# Altium schematic sheet [07] - AUDIO AND MIC.SchDoc("[07] - AUDIO AND MIC")
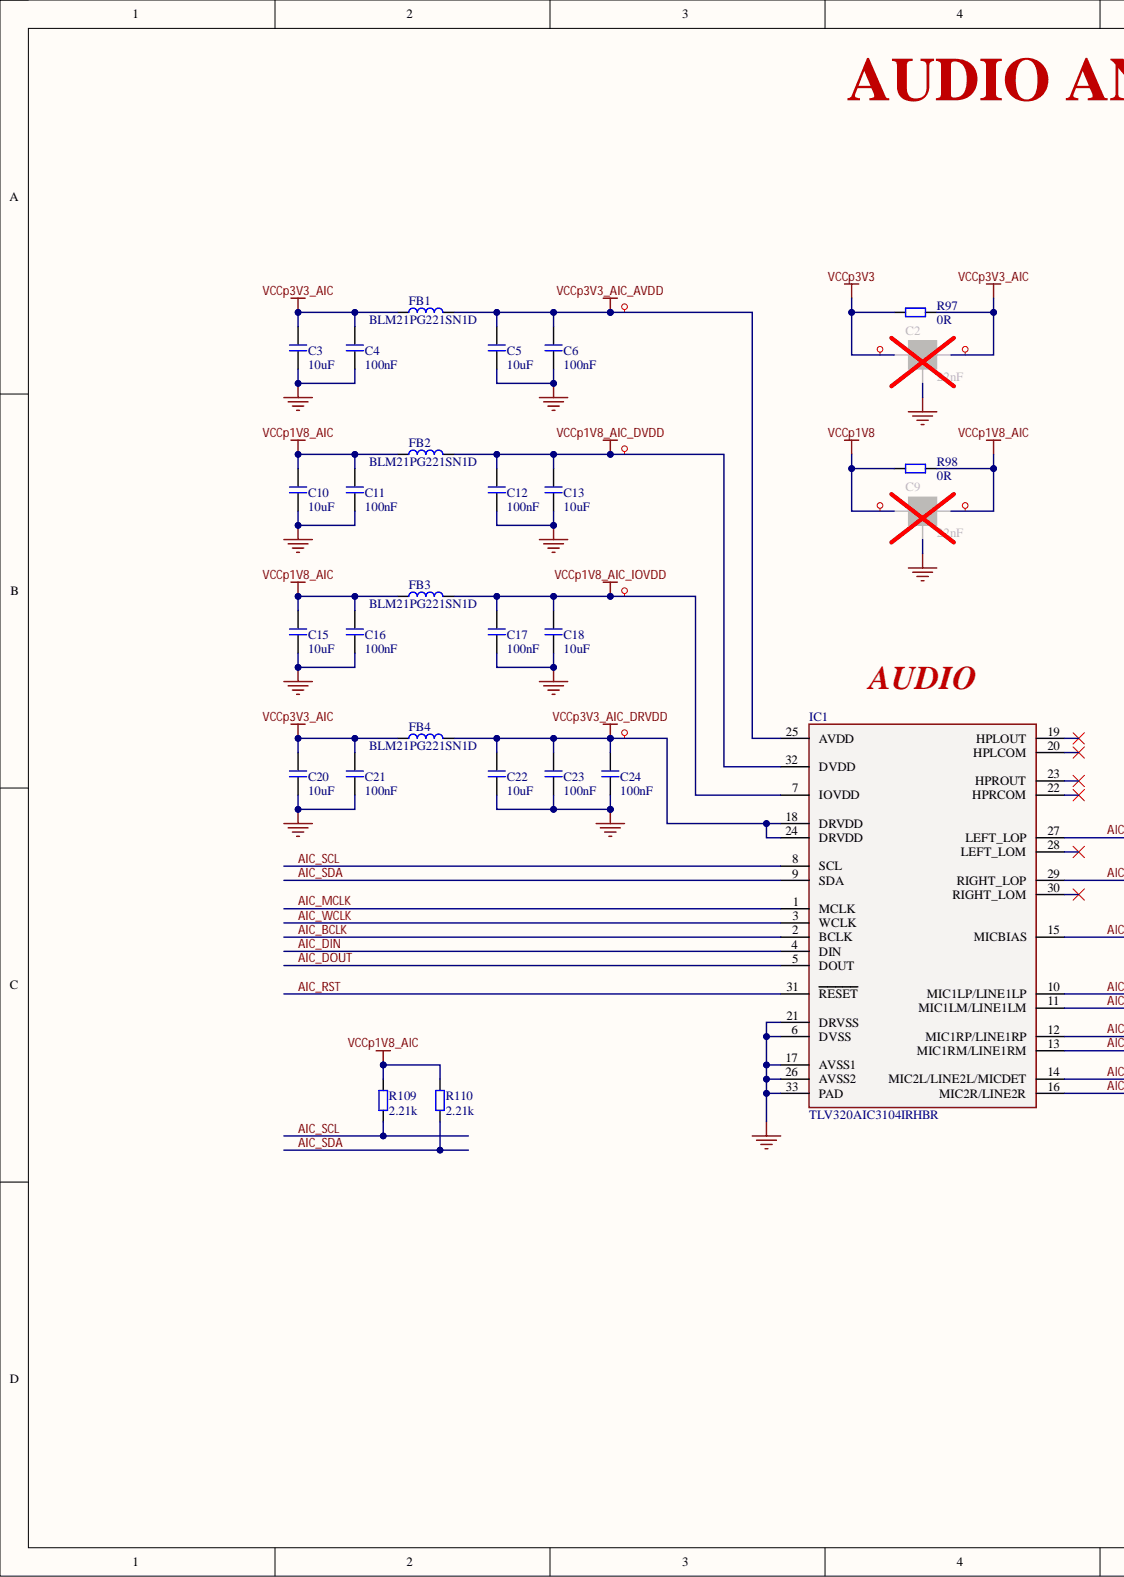
[diagram p0: [07] - AUDIO AND MIC.SchDoc, left]
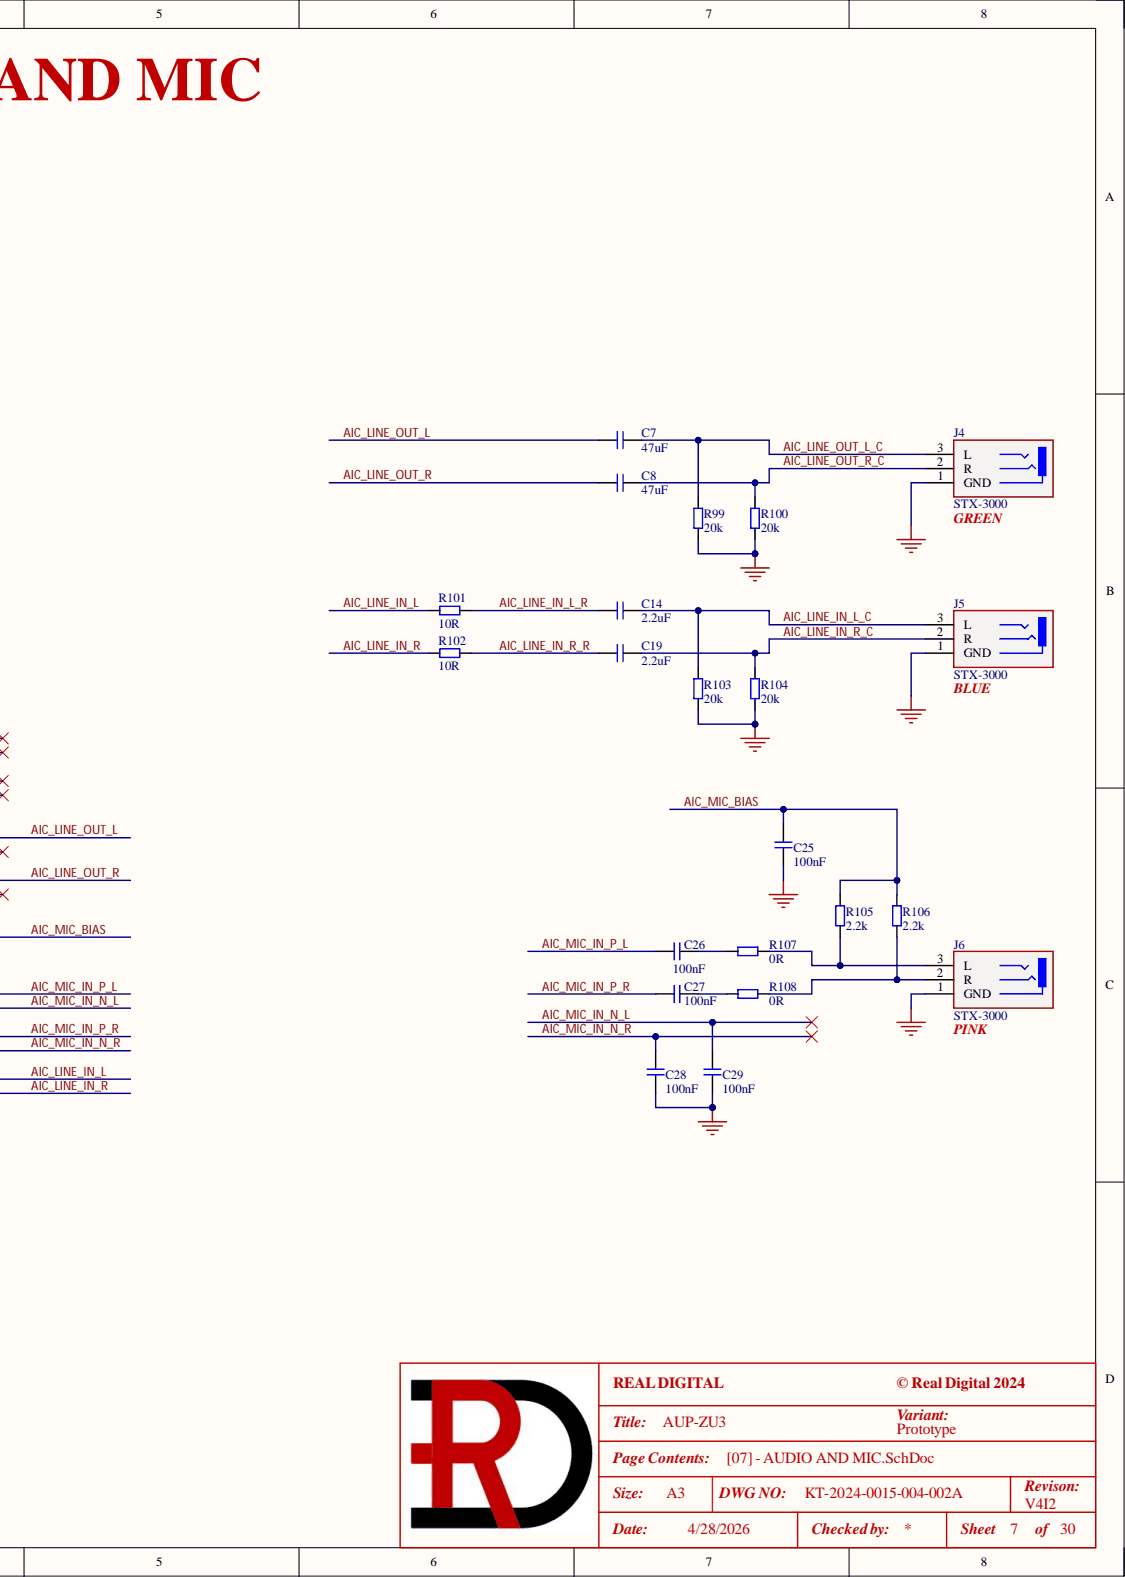
[diagram p1: [07] - AUDIO AND MIC.SchDoc, right]

## Parts
C2  22nF  [NFM31PC/31KC]
C3  10uF  [C0402]
C4  100nF  [C0402]
C5  10uF  [C0402]
C6  100nF  [C0402]
C7  47uF  [C1206]
C8  47uF  [C1206]
C9  22nF  [NFM31PC/31KC]
C10  10uF  [C0402]
C11  100nF  [C0402]
C12  100nF  [C0402]
C13  10uF  [C0402]
C14  2.2uF  [C0402]
C15  10uF  [C0402]
C16  100nF  [C0402]
C17  100nF  [C0402]
C18  10uF  [C0402]
C19  2.2uF  [C0402]
C20  10uF  [C0402]
C21  100nF  [C0402]
C22  10uF  [C0402]
C23  100nF  [C0402]
C24  100nF  [C0402]
C25  100nF  [C0402]
C26  100nF  [C0805]
C27  100nF  [C0805]
C28  100nF  [C0805]
C29  100nF  [C0805]
FB1  BLM21PG221SN1D  [BLM21]
FB2  BLM21PG221SN1D  [BLM21]
FB3  BLM21PG221SN1D  [BLM21]
FB4  BLM21PG221SN1D  [BLM21]
IC1  TLV320AIC3104IRHBR  [RHB0032E_VQFN-32 - TI]
J4  STX-3000  [PJD-035-27SDB]
J5  STX-3000  [PJD-035-27SDB]
J6  STX-3000  [PJD-035-27SDB]
R97  0R  [R0805]
R98  0R  [R0805]
R99  20k  [R0402]
R100  20k  [R0402]
R101  10R  [R0402]
R102  10R  [R0402]
R103  20k  [R0402]
R104  20k  [R0402]
R105  2.2k  [R0402]
R106  2.2k  [R0402]
R107  0R  [R0805]
R108  0R  [R0805]
R109  2.21k  [R0402]
R110  2.21k  [R0402]

## Nets
GND: C2.2 C3.1 C4.1 C5.1 C6.1 C9.2 C10.1 C11.1 C12.1 C13.1 C15.1 C16.1 C17.1 C18.1 C20.1 C21.1 C22.1 C23.1 C24.1 C25.2 C28.1 C29.1 IC1.6(DVSS) IC1.17(AVSS1) IC1.21(DRVSS) IC1.26(AVSS2) IC1.33(PAD) J4.1 J5.1 J6.1 R99.1 R100.1 R103.1 R104.1
VCCp1V8: C9.1 R98.2
VCCp1V8_AIC: C9.3 C10.2 C11.2 C15.2 C16.2 FB2.1 FB3.1 R98.1 R109.2 R110.2
VCCp1V8_AIC_DVDD: C12.2 C13.2 FB2.2 IC1.32(DVDD)
VCCp1V8_AIC_IOVDD: C17.2 C18.2 FB3.2 IC1.7(IOVDD)
VCCp3V3: C2.1 R97.2
VCCp3V3_AIC: C2.3 C3.2 C4.2 C20.2 C21.2 FB1.1 FB4.1 R97.1
VCCp3V3_AIC_AVDD: C5.2 C6.2 FB1.2 IC1.25(AVDD)
VCCp3V3_AIC_DRVDD: C22.2 C23.2 C24.2 FB4.2 IC1.18(DRVDD) IC1.24(DRVDD)
AIC_BCLK: IC1.2(BCLK)
AIC_DIN: IC1.4(DIN)
AIC_DOUT: IC1.5(DOUT)
AIC_LINE_IN_L: IC1.14(MIC2L/LINE2L/MICDET) R101.1
AIC_LINE_IN_L_C: C14.2 J5.3 R103.2
AIC_LINE_IN_L_R: C14.1 R101.2
AIC_LINE_IN_R: IC1.16(MIC2R/LINE2R) R102.1
AIC_LINE_IN_R_C: C19.2 J5.2 R104.2
AIC_LINE_IN_R_R: C19.1 R102.2
AIC_LINE_OUT_L: C7.1 IC1.27(LEFT_LOP)
AIC_LINE_OUT_L_C: C7.2 J4.3 R99.2
AIC_LINE_OUT_R: C8.1 IC1.29(RIGHT_LOP)
AIC_LINE_OUT_R_C: C8.2 J4.2 R100.2
AIC_MCLK: IC1.1(MCLK)
AIC_MIC_BIAS: C25.1 IC1.15(MICBIAS) R105.2 R106.2
AIC_MIC_IN_N_L: C29.2 IC1.11(MIC1LM/LINE1LM)
AIC_MIC_IN_N_R: C28.2 IC1.13(MIC1RM/LINE1RM)
AIC_MIC_IN_P_L: C26.1 IC1.10(MIC1LP/LINE1LP)
AIC_MIC_IN_P_R: C27.1 IC1.12(MIC1RP/LINE1RP)
AIC_RST: IC1.31(RESET)
AIC_SCL: IC1.8(SCL) R109.1
AIC_SDA: IC1.9(SDA) R110.1
AIC_WCLK: IC1.3(WCLK)
NetC26_2: C26.2 R107.1
NetC27_2: C27.2 R108.1
NetJ6_2: J6.2 R106.1 R108.2
NetJ6_3: J6.3 R105.1 R107.2

Not connected: IC1 19(HPLOUT) 20(HPLCOM) 22(HPRCOM) 23(HPROUT) 28(LEFT_LOM) 30(RIGHT_LOM)

## Library data of the parts
C2 C9 = NFM31HK223R1H3: CAP FEEDTHRU 0.022UF 50V 1206; Manufacturer 1: Murata Electronics; Manufacturer 1 Part Number: NFM31HK223R1H3L; .Checked_PCB: RV; C P/N: RD-111-0025; LoadStatus: Load; Category: Capacitors; Component Kind: Standard; Library Name: KT-RealDigital.IntLib; .Checked_SCH: RV; .Creator: RV
C3 C5 C10 C13 C15 C18 C20 C22 = GRM155R61A106ME11D: CAP CER 10uF 10V X5R 0402; Manufacturer 1: Murata Electronics; .Checked_PCB: RV; C P/N: RD-111-0065; LoadStatus: Load; Tolerance: 20%; Category: Capacitors; Component Kind: Standard; Library Name: KT-RealDigital.IntLib; Manufacturer 1 Part Number: GRM155R61A106ME11D; Temperature Coefficient: X5R; Voltage - Rated: 10V; .Checked_SCH: RV; .Creator: RV
C4 C6 C11 C12 C16 C17 C21 C23-C25 = GRM155R71H104ME14J: CAP CER 0.1uF 50V X7R 0402; Manufacturer 1: Murata Electronics; .Checked_PCB: DG; .Creator: RV; C P/N: RD-111-0069; LoadStatus: Load; Tolerance: 20%; Category: Capacitors; Component Kind: Standard; Library Name: KT-RealDigital.IntLib; Manufacturer 1 Part Number: GRM155R71H104ME14J; Temperature Coefficient: X7R; Voltage - Rated: 50V; .Checked_SCH: DG
C7 C8 = GRM31CR60J476ME19L: CAP CER 47uF 6.3V X5R 1206; Manufacturer 1: Murata Electronics; .Checked_PCB: RV; C P/N: RD-111-0098; LoadStatus: Load; Tolerance: 20%; Category: Capacitors; Component Kind: Standard; Library Name: KT-RealDigital.IntLib; Manufacturer 1 Part Number: GRM31CR60J476ME19L; Temperature Coefficient: X5R; Voltage - Rated: 6.3V; .Checked_SCH: RV; .Creator: RV
C14 C19 = GRM155R61E225KE11D: CAP CER 2.2uF 25V X5R 0402; Manufacturer 1: Murata Electronics; .Checked_PCB: RV; C P/N: RD-111-0099; LoadStatus: Load; Tolerance: 10%; Category: Capacitors; Component Kind: Standard; Library Name: KT-RealDigital.IntLib; Manufacturer 1 Part Number: GRM155R61E225KE11D; Temperature Coefficient: X5R; Voltage - Rated: 25V; .Checked_SCH: RV; .Creator: RV
C26-C29 = GCM21BR72A104KA37K: CAP CER 0.1uF 100V X7R 0805; Manufacturer 1: Murata Electronics; .Checked_PCB: RV; C P/N: 111-0782; LoadStatus: Load; Tolerance: 10%; Category: Capacitors; Component Kind: Standard; Library Name: KT-RealDigital.IntLib; Manufacturer 1 Part Number: GCM21BR72A104KA37K; Temperature Coefficient: X7R; Voltage - Rated: 100V; .Checked_SCH: RV; .Creator: RV
FB1-FB4 = BLM21PG221SN1D: FERRITE BEAD 220 OHM 0805 1LN; Manufacturer 1: Murata Electronics; .Checked_PCB: RV; C P/N: 131-0206; LoadStatus: Load; Category: Ferrite Beads; Component Kind: Standard; Library Name: KT-RealDigital.IntLib; Manufacturer 1 Part Number: BLM21PG221SN1D; .Checked_SCH: RV; .Creator: RV
IC1 = TLV320AIC3104IRHBR: Low-Power Stereo Audio Codec for Portable Audio and Telephony; Manufacturer 1: Texas Instruments; .Checked_PCB: RV; C P/N: RD-126-1522; LoadStatus: Load; Category: IC; Component Kind: Standard; Library Name: KT-RealDigital.IntLib; Manufacturer 1 Part Number: TLV320AIC3104IRHBR; .Checked_SCH: RV; .Creator: RV
J4-J6 = STX-3000: 3.50mm (0.141", 1/8", Mini Plug) - Headphone Phone Jack Stereo (3 Conductor, TRS) Connector Kinked Pin; Manufacturer 1: Kycon, Inc.; .Checked_PCB: AC; .Creator: RV; C P/N: 163-0000; LoadStatus: Load; Category: Connector; Component Kind: Standard; Library Name: KT-RealDigital.IntLib; Manufacturer 1 Part Number: STX-3000; Manufacturer 2: JKCR Electronics Co., Ltd.; Manufacturer 2 Part Number: PJD-035-27SDB; .Checked_SCH: AC
R97 R98 R107 R108 = RC0805JR-070RL: RES SMD 0 OHM JUMPER 1/8W 0805; Manufacturer 1: Yageo; .Checked_PCB: AC; .Creator: RV; C P/N: RD-101-0006; LoadStatus: Load; Category: Resistors; Component Kind: Standard; Library Name: KT-RealDigital.IntLib; Manufacturer 1 Part Number: RC0805JR-070RL; Tolerance: Jumper; .Checked_SCH: AC
R99 R100 R103 R104 = RT0402BRD0720KL: RES SMD 20K OHM 0.1% 1/16W 0402; Manufacturer 1: Yageo; .Checked_PCB: AC; .Creator: RV; C P/N: 101-1361; LoadStatus: Load; Tolerance: 0.1%/TK25; Category: Resistors; Component Kind: Standard; Library Name: KT-RealDigital.IntLib; Manufacturer 1 Part Number: RT0402BRD0720KL; .Checked_SCH: AC
R101 R102 = RC0402FR-0710RL: RES SMD 10 OHM 1% 1/16W 0402; Manufacturer 1: Yageo; .Checked_PCB: RV; C P/N: CY-101-0388; LoadStatus: Load; Tolerance: 1%/TK200; Category: Resistors; Component Kind: Standard; Library Name: KT-RealDigital.IntLib; Manufacturer 1 Part Number: RC0402FR-0710RL; .Checked_SCH: RV; .Creator: RV
R105 R106 = RT0402BRD072K2L: RES SMD 2.2K OHM 0.1% 1/16W 0402; Manufacturer 1: YAGEO; .Checked_PCB: RV; C P/N: 101-0863; LoadStatus: Load; Tolerance: 0.1%/TK25; Category: Resistors; Component Kind: Standard; Library Name: KT-RealDigital.IntLib; Manufacturer 1 Part Number: RT0402BRD072K2L; .Checked_SCH: RV; .Creator: RV
R109 R110 = RC0402FR-072K21L: RES SMD 2.21K OHM 1% 1/16W 0402; Manufacturer 1: YAGEO; .Checked_PCB: RV; C P/N: RD-101-0019; LoadStatus: Load; Tolerance: 1%/TK100; Category: Resistors; Component Kind: Standard; Library Name: KT-RealDigital.IntLib; Manufacturer 1 Part Number: RC0402FR-072K21L; .Checked_SCH: RV; .Creator: RV
